# Altium schematic sheet STM32F407VG.SchDoc
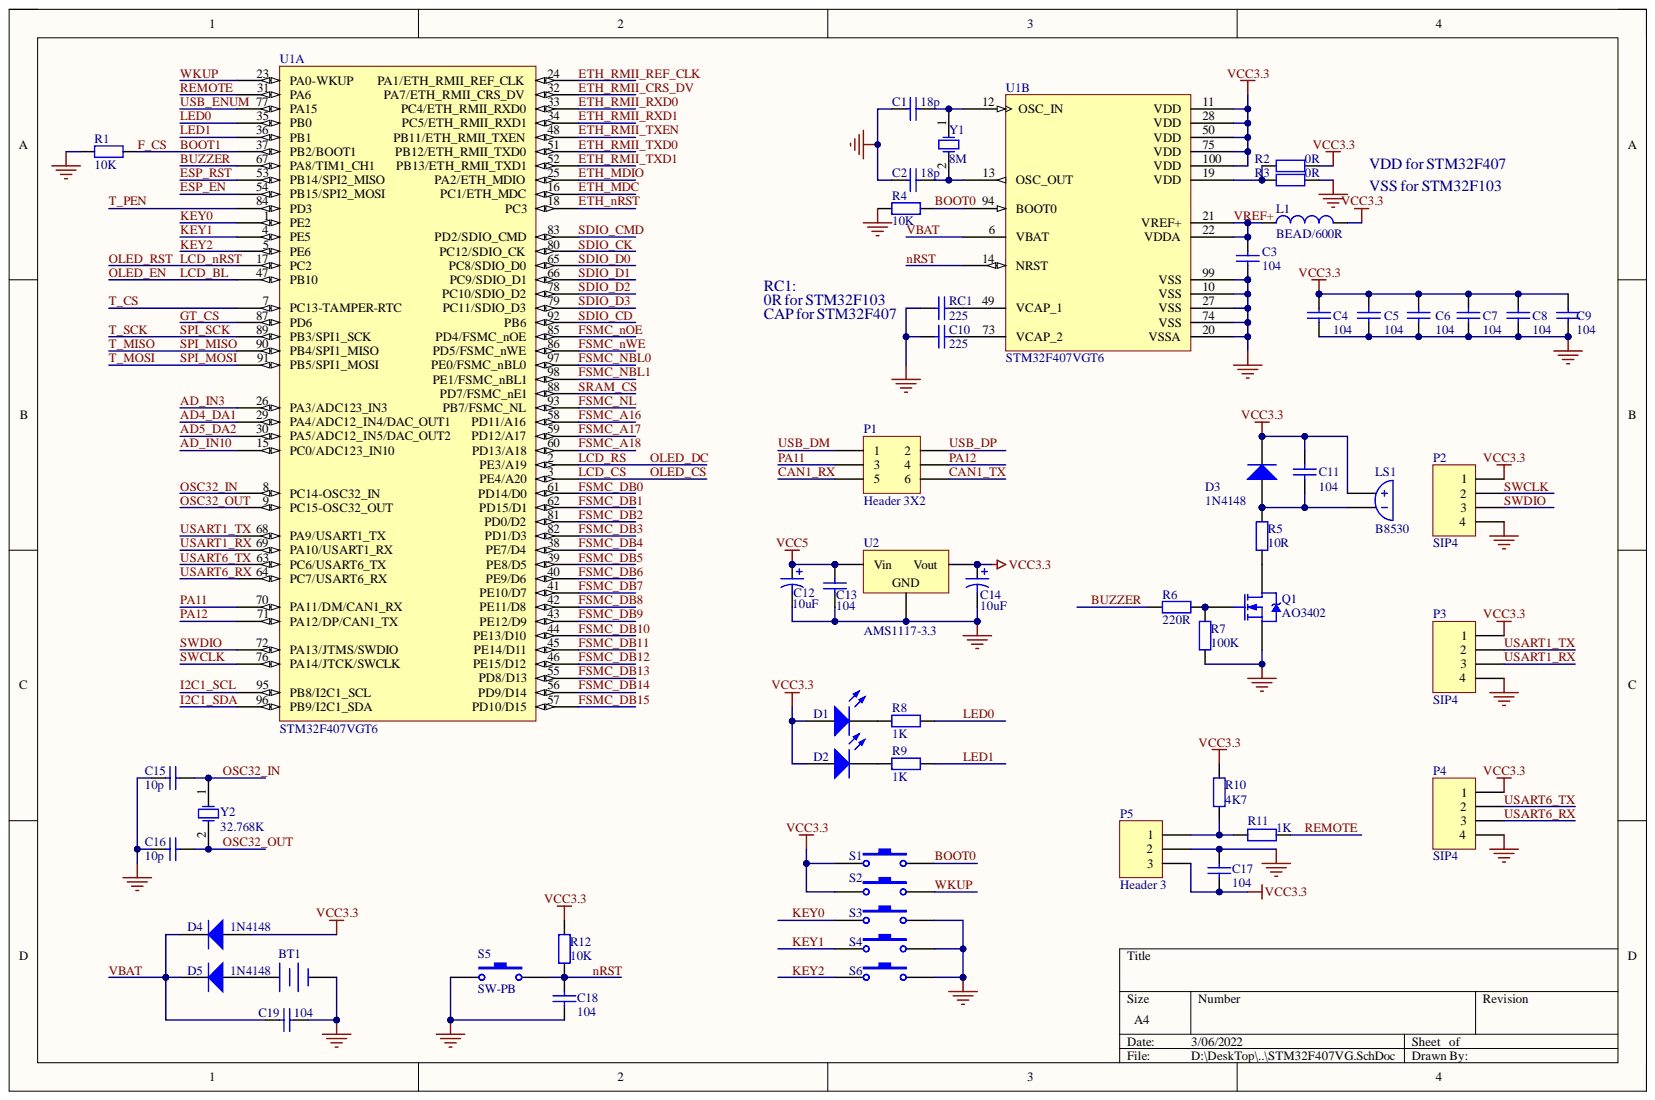
[diagram p0: STM32F407VG.SchDoc]

## Parts
BT1  Battery  [CR1220]  (value not drawn)
C1  18p  [C0603]
C2  18p  [C0603]
C3  104  [C0603]
C4  104  [C0603]
C5  104  [C0603]
C6  104  [C0603]
C7  104  [C0603]
C8  104  [C0603]
C9  104  [C0603]
C10  225  [C0805]
C11  104  [C0603]
C12  10uF  [C3216]
C13  104  [C0603]
C14  10uF  [C3216]
C15  10p  [C0805]
C16  10p  [C0805]
C17  104  [C0603]
C18  104  [C0603]
C19  104  [C0603]
D1  LED1  [LED0805]  (value not drawn)
D2  LED1  [LED0805]  (value not drawn)
D3  1N4148  [SOD323]
D4  1N4148  [SOD323]
D5  1N4148  [SOD323]
L1  BEAD/600R  [L0805]
LS1  B8530  [B8530]
P1  Header 3X2  [HDR-TH_6P-P2.54-V-M-R2-C3-S2.54-1]
P2  SIP4  [XH2P54P4]
P3  SIP4  [XH2P54P4]
P4  SIP4  [XH2P54P4]
P5  Header 3  [1838T]
Q1  AO3402  [SOT-23]
R1  10K  [R0603]
R2  0R  [R0805]
R3  0R  [R0805]
R4  10K  [R0603]
R5  10R  [R0805]
R6  220R  [R0805]
R7  100K  [R0805]
R8  1K  [R0603]
R9  1K  [R0603]
R10  4K7  [R0805]
R11  1K  [R0603]
R12  10K  [R0603]
RC1  225  [C0805]
S1  SW-PB  [Switch]  (value not drawn)
S2  SW-PB  [Switch]  (value not drawn)
S3  SW-PB  [Switch]  (value not drawn)
S4  SW-PB  [Switch]  (value not drawn)
S5  SW-PB  [Switch]
S6  SW-PB  [Switch]  (value not drawn)
U1  STM32F407VGT6  [LQFP-100]  (parts A,B)
U2  AMS1117-3.3  [SOT223]
Y1  8M  [CY5032]
Y2  32.768K  [CY3215]

## Nets
GND: BT1.2 C1.1 C2.1 C3.1 C4.1 C5.1 C6.1 C7.1 C8.1 C9.1 C10.1 C12.2 C13.1 C14.2 C15.1 C16.1 C17.2 C18.2 C19.2 P2.4 P3.4 P4.4 P5.2 Q1.3 R1.2 R3.1 R4.1 R7.1 RC1.1 S3.2 S4.2 S5.1 S6.2 U1.10(VSS) U1.20(VSSA) U1.27(VSS) U1.74(VSS) U1.99(VSS) U2.1
VCC3.3: C4.2 C5.2 C6.2 C7.2 C8.2 C9.2 C11.2 C14.1 C17.1 D1.1 D2.1 D3.2 D4.1 L1.2 LS1.1 P2.1 P3.1 P4.1 P5.3 R2.1 R10.1 R12.2 S1.1 S2.1 U1.11(VDD) U1.28(VDD) U1.50(VDD) U1.75(VDD) U1.100(VDD) U2.2
VCC5: C12.1 C13.2 U2.3
AD4_DA1: U1.29(PA4/ADC12_IN4/DAC_OUT1)
AD5_DA2: U1.30(PA5/ADC12_IN5/DAC_OUT2)
AD_IN3: U1.26(PA3/ADC123_IN3)
AD_IN10: U1.15(PC0/ADC123_IN10)
BOOT0: R4.2 S1.2 U1.94(BOOT0)
BOOT1: R1.1 U1.37(PB2/BOOT1)
BUZZER: R6.1 U1.67(PA8/TIM1_CH1)
CAN1_RX: P1.5
CAN1_TX: P1.6
ESP_EN: U1.54(PB15/SPI2_MOSI)
ESP_RST: U1.53(PB14/SPI2_MISO)
ETH_MDC: U1.16(PC1/ETH_MDC)
ETH_MDIO: U1.25(PA2/ETH_MDIO)
ETH_nRST: U1.18(PC3)
ETH_RMII_CRS_DV: U1.32(PA7/ETH_RMII_CRS_DV)
ETH_RMII_REF_CLK: U1.24(PA1/ETH_RMII_REF_CLK)
ETH_RMII_RXD0: U1.33(PC4/ETH_RMII_RXD0)
ETH_RMII_RXD1: U1.34(PC5/ETH_RMII_RXD1)
ETH_RMII_TXD0: U1.51(PB12/ETH_RMII_TXD0)
ETH_RMII_TXD1: U1.52(PB13/ETH_RMII_TXD1)
ETH_RMII_TXEN: U1.48(PB11/ETH_RMII_TXEN)
FSMC_A16: U1.58(PD11/A16)
FSMC_A17: U1.59(PD12/A17)
FSMC_A18: U1.60(PD13/A18)
FSMC_DB0: U1.61(PD14/D0)
FSMC_DB1: U1.62(PD15/D1)
FSMC_DB2: U1.81(PD0/D2)
FSMC_DB3: U1.82(PD1/D3)
FSMC_DB4: U1.38(PE7/D4)
FSMC_DB5: U1.39(PE8/D5)
FSMC_DB6: U1.40(PE9/D6)
FSMC_DB7: U1.41(PE10/D7)
FSMC_DB8: U1.42(PE11/D8)
FSMC_DB9: U1.43(PE12/D9)
FSMC_DB10: U1.44(PE13/D10)
FSMC_DB11: U1.45(PE14/D11)
FSMC_DB12: U1.46(PE15/D12)
FSMC_DB13: U1.55(PD8/D13)
FSMC_DB14: U1.56(PD9/D14)
FSMC_DB15: U1.57(PD10/D15)
FSMC_NBL0: U1.97(PE0/FSMC_nBL0)
FSMC_NBL1: U1.98(PE1/FSMC_nBL1)
FSMC_NL: U1.93(PB7/FSMC_NL)
FSMC_nOE: U1.85(PD4/FSMC_nOE)
FSMC_nWE: U1.86(PD5/FSMC_nWE)
GT_CS: U1.87(PD6)
I2C1_SCL: U1.95(PB8/I2C1_SCL)
I2C1_SDA: U1.96(PB9/I2C1_SDA)
KEY0: S3.1 U1.1(PE2)
KEY1: S4.1 U1.4(PE5)
KEY2: S6.1 U1.5(PE6)
LCD_BL: U1.47(PB10)
LCD_CS: U1.3(PE4/A20)
LCD_nRST: U1.17(PC2)
LCD_RS: U1.2(PE3/A19)
LED0: R8.1 U1.35(PB0)
LED1: R9.1 U1.36(PB1)
nRST: C18.1 R12.1 S5.2 U1.14(NRST)
OSC32_IN: C15.2 U1.8(PC14-OSC32_IN) Y2.1
OSC32_OUT: C16.2 U1.9(PC15-OSC32_OUT) Y2.2
PA11: P1.3 U1.70(PA11/DM/CAN1_RX)
PA12: P1.4 U1.71(PA12/DP/CAN1_TX)
REMOTE: R11.1 U1.31(PA6)
SDIO_CD: U1.92(PB6)
SDIO_CK: U1.80(PC12/SDIO_CK)
SDIO_CMD: U1.83(PD2/SDIO_CMD)
SDIO_D0: U1.65(PC8/SDIO_D0)
SDIO_D1: U1.66(PC9/SDIO_D1)
SDIO_D2: U1.78(PC10/SDIO_D2)
SDIO_D3: U1.79(PC11/SDIO_D3)
SPI_MISO: U1.90(PB4/SPI1_MISO)
SPI_MOSI: U1.91(PB5/SPI1_MOSI)
SPI_SCK: U1.89(PB3/SPI1_SCK)
SRAM_CS: U1.88(PD7/FSMC_nE1)
SWCLK: P2.2 U1.76(PA14/JTCK/SWCLK)
SWDIO: P2.3 U1.72(PA13/JTMS/SWDIO)
T_CS: U1.7(PC13-TAMPER-RTC)
T_PEN: U1.84(PD3)
USART1_RX: P3.3 U1.69(PA10/USART1_RX)
USART1_TX: P3.2 U1.68(PA9/USART1_TX)
USART6_RX: P4.3 U1.64(PC7/USART6_RX)
USART6_TX: P4.2 U1.63(PC6/USART6_TX)
USB_DM: P1.1
USB_DP: P1.2
USB_ENUM: U1.77(PA15)
VBAT: C19.1 D4.2 D5.2 U1.6(VBAT)
VREF+: C3.2 L1.1 U1.21(VREF+) U1.22(VDDA)
WKUP: S2.2 U1.23(PA0-WKUP)
NetBT1_1: BT1.1 D5.1
NetC1_2: C1.2 U1.12(OSC_IN) Y1.1
NetC2_2: C2.2 U1.13(OSC_OUT) Y1.2
NetC10_2: C10.2 U1.73(VCAP_2)
NetC11_1: C11.1 D3.1 LS1.2 R5.2
NetD1_2: D1.2 R8.2
NetD2_2: D2.2 R9.2
NetP5_1: P5.1 R10.2 R11.2
NetQ1_1: Q1.1 R6.2 R7.2
NetQ1_2: Q1.2 R5.1
NetR2_2: R2.2 R3.2 U1.19(VDD)
NetRC1_2: RC1.2 U1.49(VCAP_1)

## Library data of the parts
BT1 = Battery: Multicell Battery; Component Kind: Standard; LatestRevisionDate: 17-Jul-2002; LatestRevisionNote: Re-released for DXP Platform.; Library Name: MainBoard.SCHLIB; PackageDescription: Battery; 2 Leads; PackageReference: BAT-2; Published: 24-Mar-1999; Publisher: Altium Limited
C1-C11 C13 C15-C19 RC1 = Cap: Capacitor; Value: 100pF; Component Kind: Standard; LatestRevisionDate: 17-Jul-2002; LatestRevisionNote: Re-released for DXP Platform.; Library Name: MainBoard.SCHLIB; PackageDescription: Radial Cap, Thru-Hole; 2 Leads; 0.3 in Pin Spacing; PackageReference: RAD-0.3; Published: 8-Jun-2000; Publisher: Altium Limited
C12 C14 = Cap Pol2: Polarized Capacitor (Axial); Component Kind: Standard; LatestRevisionDate: 17-Jul-2002; LatestRevisionNote: Re-released for DXP Platform.; PackageDescription: Polarized Capacitor; 2 Leads; PackageReference: POLAR0.8; Published: 8-Jun-2000; Signal Integrity: Cap Pol; Simulation: CAP; Library Name: Scanner.SchLib; Publisher: Altium Limited
D1 D2 = LED1: Typical RED GaAs LED; Component Kind: Standard; LatestRevisionDate: 08-Jul-2005; LatestRevisionNote: Mech Layer 1 removed.; Library Name: MainBoard.SCHLIB; PackageDescription: LED; 2 Leads; PackageReference: LED-1; Published: 8-Jun-2000; Publisher: Altium Limited
D3 = Diode 1N4007: 二极管; Code_JEDEC: DO-204-AL; Component Kind: Standard; ComponentLink1Description: Manufacturer Link; ComponentLink1URL: http://www.fairchildsemi.com/; ComponentLink2Description: Datasheet; ComponentLink2URL: http://www.fairchildsemi.com/ds/1N%2F1N4001.pdf; DatasheetVersion: 1998; LatestRevisionDate: 17-Jul-2002; LatestRevisionNote: Re-released for DXP Platform.; Library Name: Miscellaneous Devices.IntLib; PackageReference: DO-41; PackageVersion: Aug-1999; Published: 8-Jun-2000; Publisher: Altium Limited
D4 D5 = Diode 1N4148: High Conductance Fast Diode; Code_JEDEC: DO-204-AH; Component Kind: Standard; ComponentLink1Description: Manufacturer Link; ComponentLink1URL: http://www.fairchildsemi.com/; ComponentLink2Description: Datasheet; ComponentLink2URL: http://www.fairchildsemi.com/ds/1N%2F1N4148.pdf; DatasheetVersion: 1997; LatestRevisionDate: 17-Jul-2002; LatestRevisionNote: Re-released for DXP Platform.; Library Name: MainBoard.SchLib; PackageDescription: Diode, Axial; Body 3.8 x 1.9 mm (LxDia. max), Lead Dia. 0.53 mm (max); PackageReference: DO-35; Published: 8-Jun-2000; Publisher: Altium Limited
L1 = Inductor: Code_IEC: 1005; Code_JEITA: 0402; Component Kind: Standard; Description: Inductor; LatestRevisionDate: 29-May-2009; LatestRevisionNote: IPC-7351 Footprint Added.; Library Name: Miscellaneous Devices.IntLib; PackageDescription: Chip Inductor; PackageReference: 0402-A; Published: 8-Jun-2000; Signal Integrity: Inductor; Simulation: INDUCTOR; Publisher: Altium Limited
LS1 = B8530: Magnetic Transducer Buzzer; Component Kind: Standard; ComponentLink1Description: Manufacturer Link; ComponentLink1URL: http://www.megastar.com/linecard/buzzmode/index.php; DatasheetVersion: 17-Dec-2003; LatestRevisionDate: 17-Dec-2003; LatestRevisionNote: Schematic revised, PCB Footprint replaced.; Library Name: LCD_CONTROL.SCHLIB; PackageReference: ABSM-1574; Published: 24-Mar-1999; Publisher: Altium Limited
P1 = Header 3X2: Header, 3-Pin, Dual row; Component Kind: Standard; LatestRevisionDate: 17-Jul-2002; LatestRevisionNote: Re-released for DXP Platform.; Library Name: Miscellaneous Connectors.IntLib; Signal Integrity: Connector; Publisher: Altium Limited
P2-P4 = Header 4: 贴片针; Component Kind: Standard; LatestRevisionDate: 17-Jul-2002; LatestRevisionNote: Re-released for DXP Platform.; Library Name: Miscellaneous Connectors.IntLib; Signal Integrity: Connector; Publisher: Altium Limited
P5 = Header 3: Header, 3-Pin; Component Kind: Standard; LatestRevisionDate: 17-Jul-2002; LatestRevisionNote: Re-released for DXP Platform.; Library Name: Miscellaneous Connectors.IntLib; Signal Integrity: Connector; Publisher: Altium Limited
Q1 = NMOS-2: NMOS管; Note: Pin 2 is electrically connected to pin 4.; Code_JEDEC: TO-220-AB; Component Kind: Standard; ComponentLink1Description: Manufacturer Link; ComponentLink1URL: http://www.irf.com/; DatasheetVersion: PD-9.814; LatestRevisionDate: 17-Jul-2002; LatestRevisionNote: Re-released for DXP Platform.; Library Name: Miscellaneous Devices.IntLib; PackageReference: TO-220AB; Published: 8-Jun-2000; Publisher: Altium Limited
R1-R4 R8-R12 = Res: Resistor; Component Kind: Standard; LatestRevisionDate: 17-Jul-2002; LatestRevisionNote: Re-released for DXP Platform.; PackageDescription: Axial Device, Thru-Hole; 2 Leads; 0.4 in Pin Spacing; PackageReference: AXIAL-0.4; Published: 8-Jun-2000; Publisher: Altium Limited
  R1 R4 R12: Library Name: MainBoard.SchLib
  R2 R3 R8-R11: Library Name: MainBoard.SCHLIB
R5-R7 = Res2: 电阻; Value: 1K; Component Kind: Standard; LatestRevisionDate: 17-Jul-2002; LatestRevisionNote: Re-released for DXP Platform.; Library Name: Miscellaneous Devices.IntLib; PackageReference: R0603; Published: 8-Jun-2000; Signal Integrity: Res; Simulation: RESISTOR; Publisher: Altium Limited
S1-S6 = SW-PB: Switch; Component Kind: Standard; LatestRevisionDate: 17-Jul-2002; LatestRevisionNote: Re-released for DXP Platform.; Library Name: MainBoard.SchLib; PackageDescription: Switch; 2 Leads; PackageReference: SPST-2; Published: 24-Mar-1999; Publisher: Altium Limited
U2 = Volt Reg: Voltage Regulator; Component Kind: Standard; LatestRevisionDate: 29-May-2009; LatestRevisionNote: IPC-7351 Footprint Added.; Library Name: MainBoard.SCHLIB; PackageDescription: 3-Pin Transistor Outline Package 2.54 mm Pitch, 15.1 mm Lead Span; PackageReference: D2PAK; Published: 24-Mar-1999; Publisher: Altium Limited
Y1 Y2 = XTAL: Crystal Oscillator; Component Kind: Standard; LatestRevisionDate: 17-Jul-2002; LatestRevisionNote: Re-released for DXP Platform.; Library Name: MainBoard.SCHLIB; PackageDescription: Crystal, Thru-Hole; 2 Leads; Body 3.1 x 8.2 mm (Dia.xH); PackageReference: R38; Published: 8-Jun-2000; Publisher: Altium Limited
U1 = STM32F407VGT6: Component Kind: Standard; ComponentLink1Description: Manufacturer Link; ComponentLink1URL: www.st.com/stm32; ComponentLink2Description: Datasheet; ComponentLink2URL: http://www.st.com/stonline/products/literature/ds/13587.pdf; DatasheetVersion: rev6, Mar-2008; Library Name: MainBoard.SchLib; PackageDescription: 100-Pin Low-Profile Quad Flat Pack, 14 x 14 mm Body, 0.5 mm Pitch (LQFP100); PackageReference: LQFP100; PackageVersion: Mar-2008; Published: 30-May-2008; Publisher: Altium Limited
